# Altium schematic sheet PAGE2 - PWR, MODULE.SchDoc
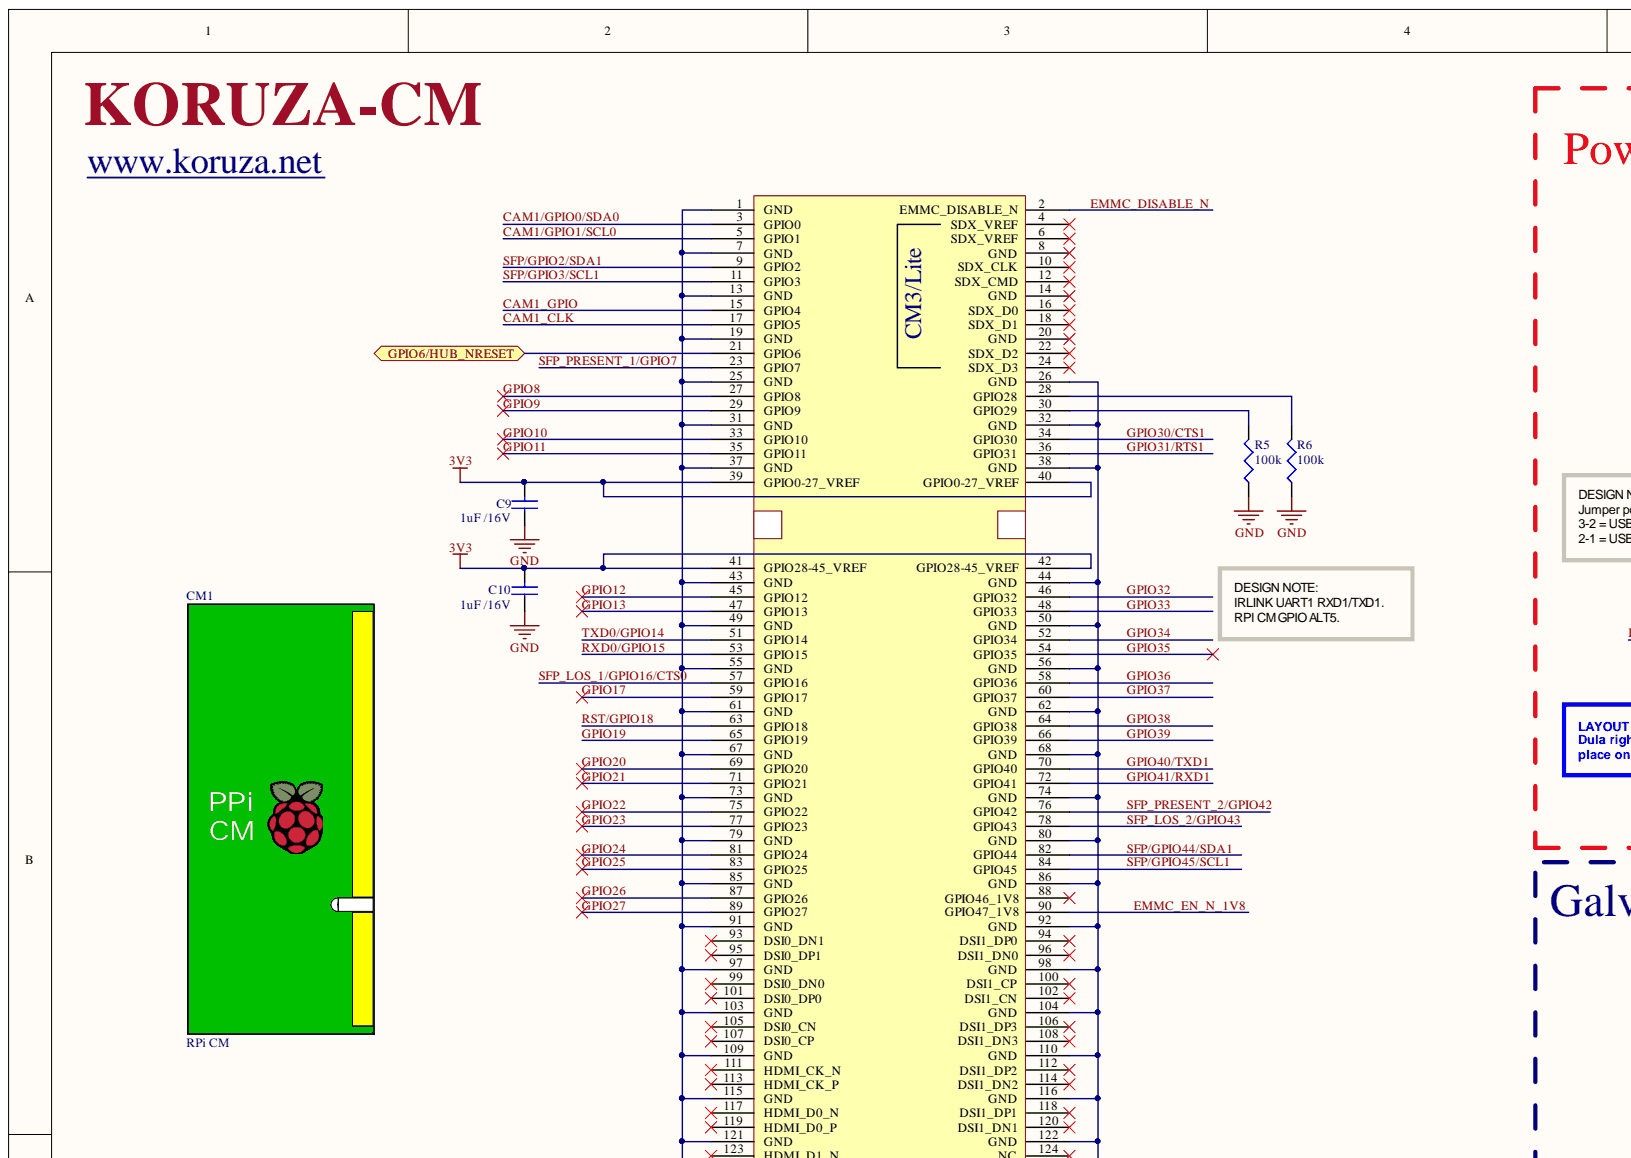
[diagram p0: PAGE2 - PWR, MODULE.SchDoc, top left]
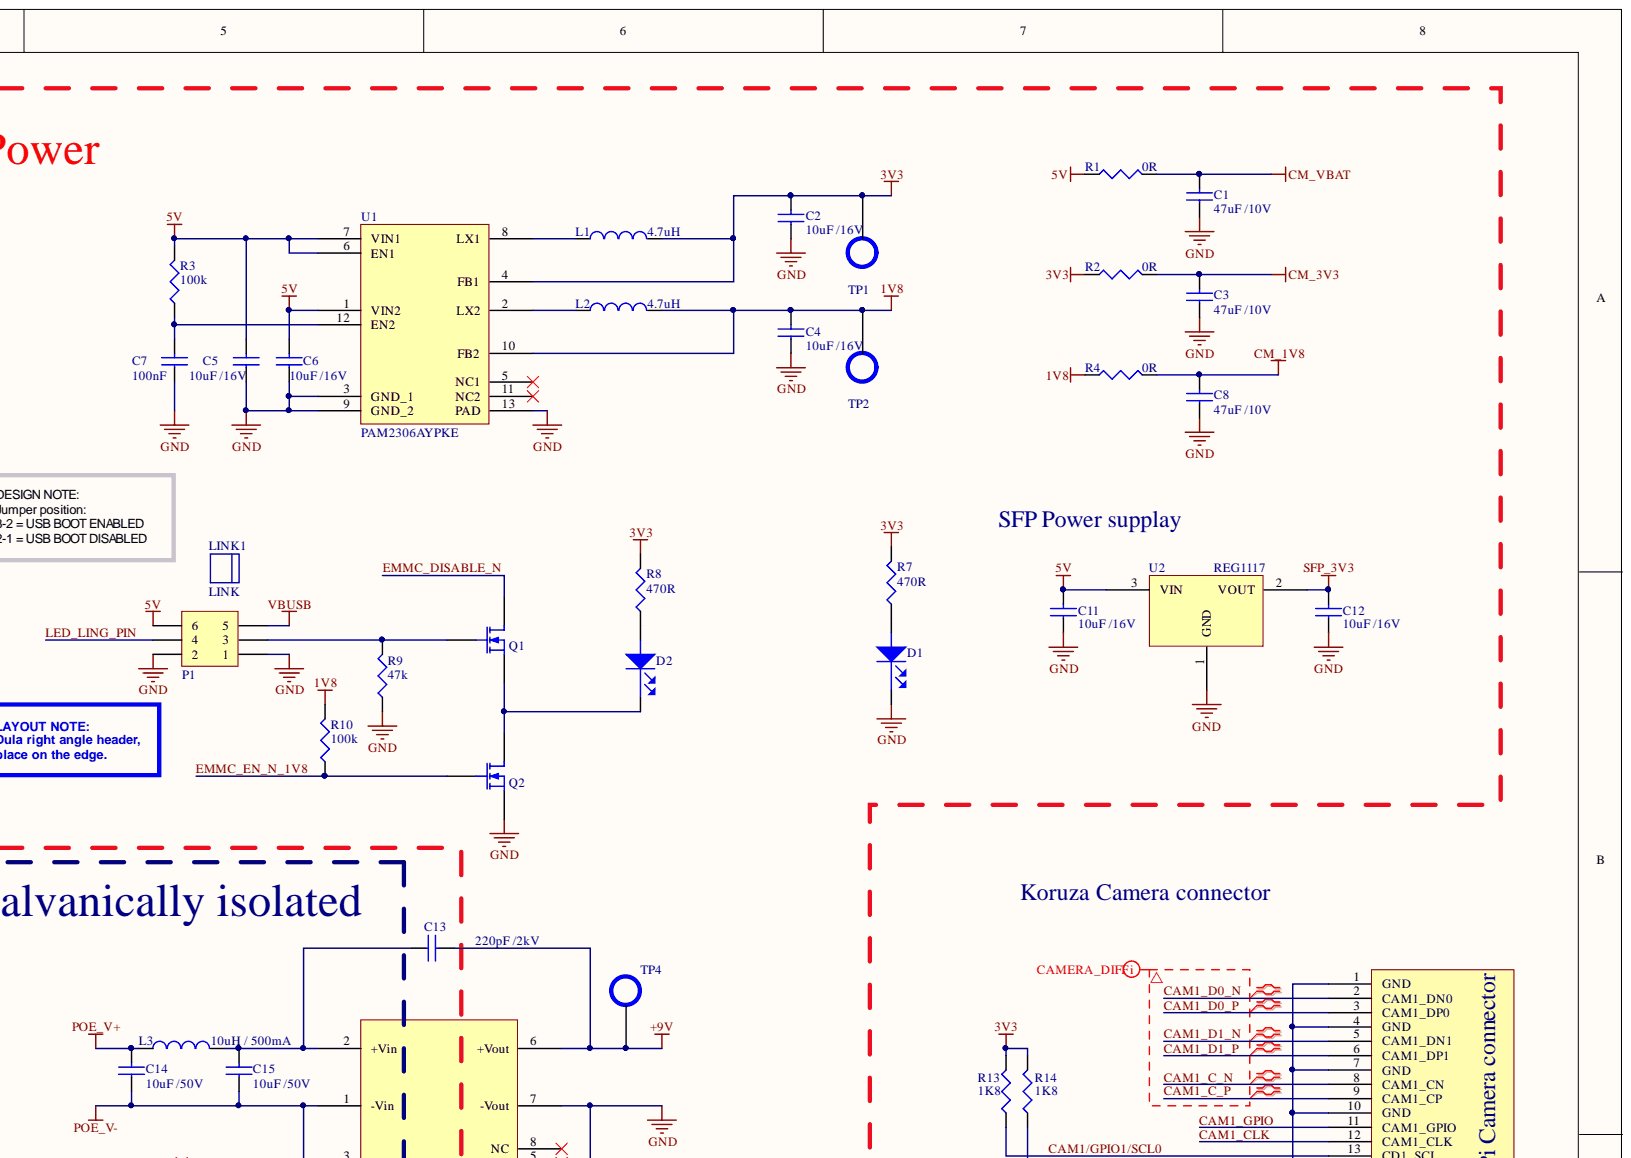
[diagram p1: PAGE2 - PWR, MODULE.SchDoc, top right]
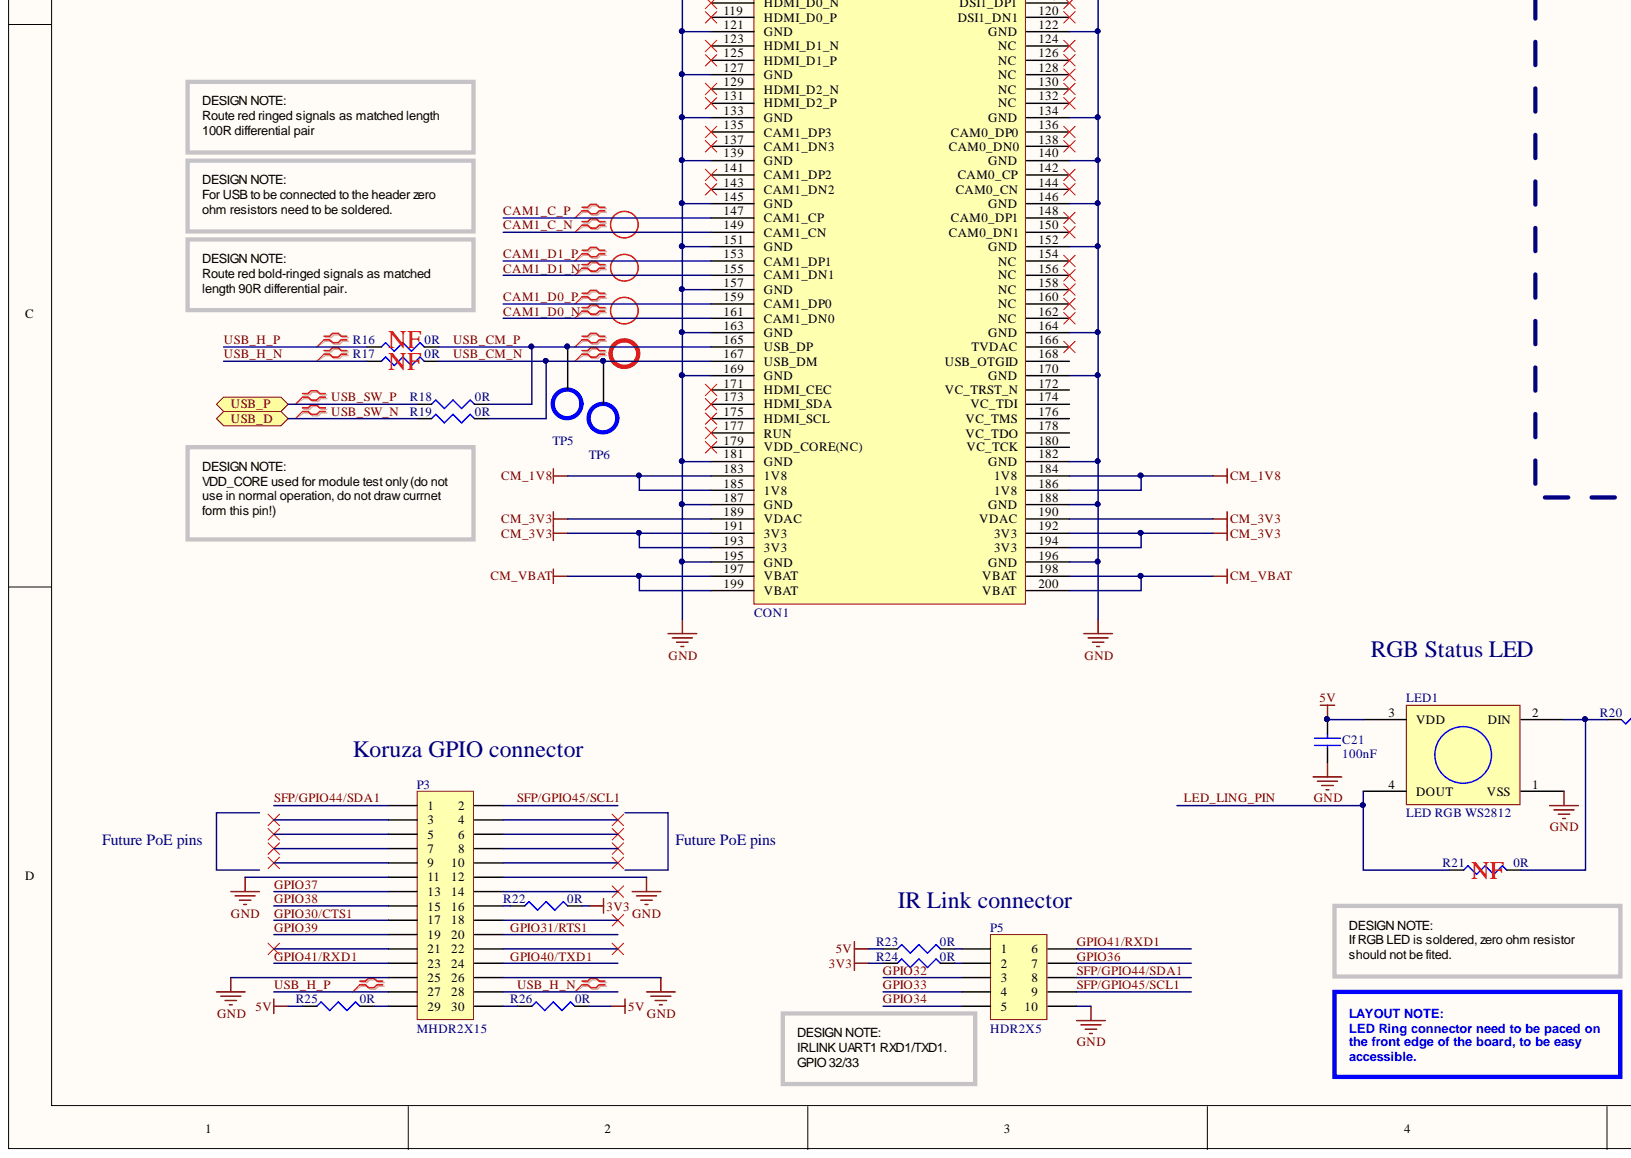
[diagram p2: PAGE2 - PWR, MODULE.SchDoc, bottom left]
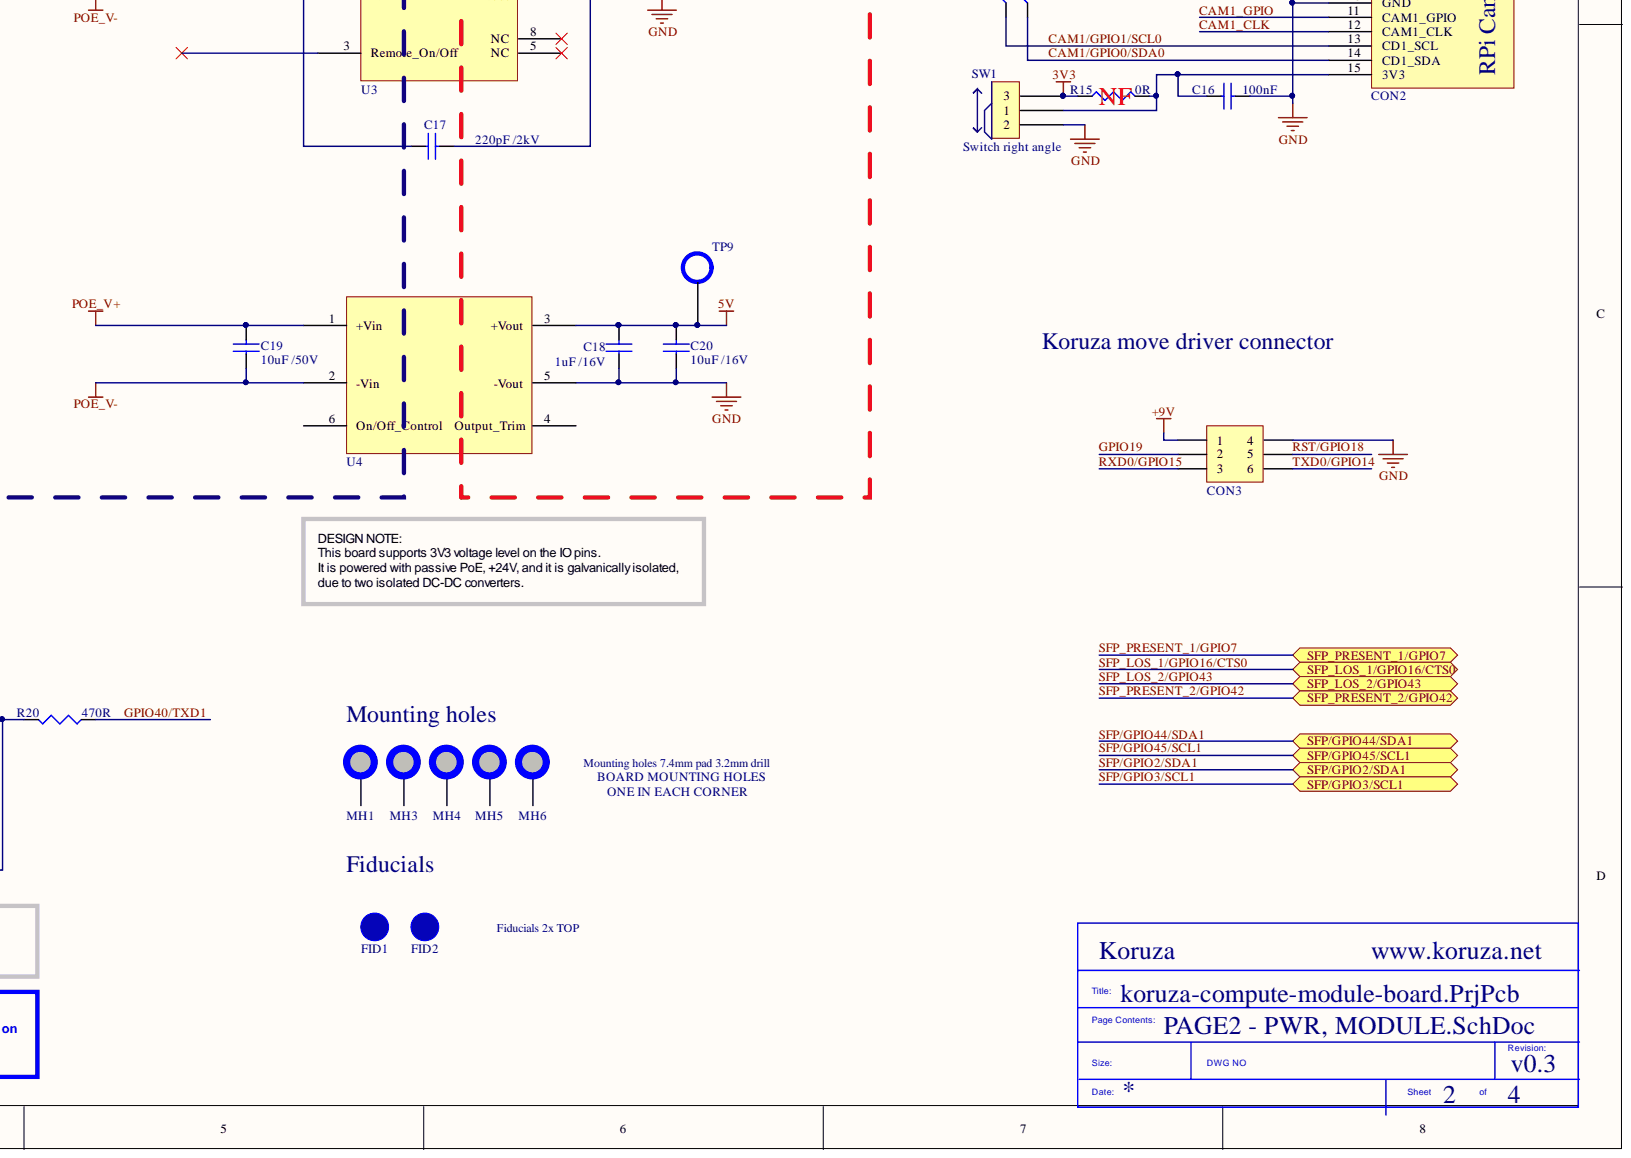
[diagram p3: PAGE2 - PWR, MODULE.SchDoc, bottom right]

## Parts
C1  47uF /10V  [CAP0805]
C2  10uF /16V  [CAP0805]
C3  47uF /10V  [CAP0805]
C4  10uF /16V  [CAP0805]
C5  10uF /16V  [CAP0805]
C6  10uF /16V  [CAP0805]
C7  100nF  [CAP0603]
C8  47uF /10V  [CAP0805]
C9  1uF /16V  [CAP0603]
C10  1uF /16V  [CAP0603]
C11  10uF /16V  [CAP0603]
C12  10uF /16V  [CAP0603]
C13  220pF /2kV  [CAP1206]
C14  10uF /50V  [CAP1206]
C15  10uF /50V  [CAP1206]
C16  100nF  [CAP0603]
C17  220pF /2kV  [CAP1206]
C18  1uF /16V  [CAP0805]
C19  10uF /50V  [CAP1206]
C20  10uF /16V  [CAP0805]
C21  100nF  [CAP0603]
CM1  RPi CM  (mechanical)
CON1  1473005-4  [BTB-SM0-6-2V200]  (value not drawn)
CON2  value Camera  [1-1734248-5]  (hidden comment: 1-1734248-5)
CON3  MICROMATCH 6 SMD  [MICROMATCH2X3]  (value not drawn)
D1  LED RED  [LED0603]  (value not drawn)
D2  LED RED  [LED0603]  (value not drawn)
FID1  FID_1MMC  [FID_40X120D]  (value not drawn)  (no BOM)
FID2  FID_1MMC  [FID_40X120D]  (value not drawn)  (no BOM)
L1  value 4.7uH  [INDP4040X18N]  (hidden comment: SRN4018-4R7M)
L2  value 4.7uH  [INDP4040X18N]  (hidden comment: SRN4018-4R7M)
L3  10uH / 500mA  [IND1212]
LED1  LED RGB WS2812  [LED_SMD5050]
LINK1  LINK  [JUMPER]  (mechanical)
MH1  MH_7.4MMNC_3.2MMD  [MH_7.4MMD_3.2MMD_NON_COPPER_NPTH]  (value not drawn)  (no BOM)
MH3  MH_7.4MMNC_3.2MMD  [MH_7.4MMD_3.2MMD_NON_COPPER_NPTH]  (value not drawn)  (no BOM)
MH4  MH_7.4MMNC_3.2MMD  [MH_7.4MMD_3.2MMD_NON_COPPER_NPTH]  (value not drawn)  (no BOM)
MH5  MH_7.4MMNC_3.2MMD  [MH_7.4MMD_3.2MMD_NON_COPPER_NPTH]  (value not drawn)  (no BOM)
MH6  MH_7.4MMNC_3.2MMD  [MH_7.4MMD_3.2MMD_NON_COPPER_NPTH]  (value not drawn)  (no BOM)
P1  MHDR2X3H  [HDR2X3_2.54MM_ANGLE]  (value not drawn)
P3  MHDR2X15  [HDR2X15]
P5  HDR2X5  [HDR2X5_CEN]
Q1  DMG1012T-7  [DMG1012T-7]  (value not drawn)
Q2  DMG1012T-7  [DMG1012T-7]  (value not drawn)
R1  0R  [RES1206]
R2  0R  [RES1206]
R3  100k  [RES0603]
R4  0R  [RES1206]
R5  100k  [RES0603]
R6  100k  [RES0603]
R7  470R  [RES0603]
R8  470R  [RES0603]
R9  47k  [RES0603]
R10  100k  [RES0603]
R13  1K8  [RES0603]
R14  1K8  [RES0603]
R15  0R  [RES0603]
R16  0R  [RES0603]
R17  0R  [RES0603]
R18  0R  [RES0603]
R19  0R  [RES0603]
R20  470R  [RES0603]
R21  0R  [RES0603]
R22  0R  [RES0603]
R23  0R  [RES0603]
R24  0R  [RES0603]
R25  0R  [RES0603]
R26  0R  [RES0603]
SW1  Switch right angle  [SW ANGLE 3PIN]
TP1  TEST_POINT  [TEST_POINT]  (value not drawn)  (no BOM)
TP2  TEST_POINT  [TEST_POINT]  (value not drawn)  (no BOM)
TP4  TEST_POINT  [TEST_POINT]  (value not drawn)  (no BOM)
TP5  TEST_POINT  [TEST_POINT]  (value not drawn)  (no BOM)
TP6  TEST_POINT  [TEST_POINT]  (value not drawn)  (no BOM)
TP9  TEST_POINT  [TEST_POINT]  (value not drawn)  (no BOM)
U1  PAM2306AYPKE  [WDFN12L]
U2  REG1117  [TI-DCY4_N]
U3  ITX2409SA  [DCDC_M1]  (value not drawn)
U4  SPM15-050-Q12P-C  [DCDC_M2]  (value not drawn)

## Ports
GPIO6/HUB_NRESET, SFP/GPIO2/SDA1, SFP/GPIO3/SCL1, SFP/GPIO44/SDA1, SFP/GPIO45/SCL1, SFP_LOS_1/GPIO16/CTS0, SFP_LOS_2/GPIO43, SFP_PRESENT_1/GPIO7, SFP_PRESENT_2/GPIO42, USB_D, USB_P

## Nets
1V8: C4.2 L2.2 R4.1 R10.2 TP2.1 U1.10(FB2)
3V3: C2.2 C9.2 C10.2 CON1.39(GPIO0-27_VREF) CON1.40(GPIO0-27_VREF) CON1.41(GPIO28-45_VREF) CON1.42(GPIO28-45_VREF) L1.2 R2.1 R7.2 R8.2 R13.2 R14.2 R15.1 R22.2 R24.1 SW1.3 TP1.1 U1.4(FB1)
5V: C5.2 C6.2 C11.2 C18.2 C20.2 C21.2 LED1.3(VDD) P1.6 R1.1 R3.2 R23.1 R25.1 R26.2 TP9.1 U1.1(VIN2) U1.6(EN1) U1.7(VIN1) U2.3 U4.3(+Vout)
+9V: C13.2 CON3.1 TP4.1 U3.6(+Vout)
CM_1V8: C8.2 CON1.183(1V8) CON1.184(1V8) CON1.185(1V8) CON1.186(1V8) R4.2
CM_3V3: C3.2 CON1.189(VDAC) CON1.190(VDAC) CON1.191(3V3) CON1.192(3V3) CON1.193(3V3) CON1.194(3V3) R2.2
CM_VBAT: C1.2 CON1.197(VBAT) CON1.198(VBAT) CON1.199(VBAT) CON1.200(VBAT) R1.2
GND: C1.1 C2.1 C3.1 C4.1 C5.1 C6.1 C7.1 C8.1 C9.1 C10.1 C11.1 C12.1 C16.2 C17.2 C18.1 C20.1 C21.1 CON1.1(GND) CON1.7(GND) CON1.13(GND) CON1.19(GND) CON1.25(GND) CON1.26(GND) CON1.31(GND) CON1.32(GND) CON1.37(GND) CON1.38(GND) CON1.43(GND) CON1.44(GND) CON1.49(GND) CON1.50(GND) CON1.55(GND) CON1.56(GND) CON1.61(GND) CON1.62(GND) CON1.67(GND) CON1.68(GND) CON1.73(GND) CON1.74(GND) CON1.79(GND) CON1.80(GND) CON1.85(GND) CON1.86(GND) CON1.91(GND) CON1.92(GND) CON1.97(GND) CON1.98(GND) CON1.103(GND) CON1.104(GND) CON1.109(GND) CON1.110(GND) CON1.115(GND) CON1.116(GND) CON1.121(GND) CON1.122(GND) CON1.127(GND) CON1.133(GND) CON1.134(GND) CON1.139(GND) CON1.140(GND) CON1.145(GND) CON1.146(GND) CON1.151(GND) CON1.152(GND) CON1.157(GND) CON1.163(GND) CON1.164(GND) CON1.169(GND) CON1.170(GND) CON1.181(GND) CON1.182(GND) CON1.187(GND) CON1.188(GND) CON1.195(GND) CON1.196(GND) CON2.1(GND) CON2.4(GND) CON2.7(GND) CON2.10(GND) CON3.4 D1.1 LED1.1(VSS) P1.1 P1.2 P3.11 P3.12 P3.25 P3.26 P5.10 Q2.3 R5.1 R6.1 R9.1 SW1.2 U1.3(GND_1) U1.9(GND_2) U1.13(PAD) U2.1 U3.7(-Vout) U4.5(-Vout)
POE_V+: C14.2 C19.2 L3.1 U4.1(+Vin)
POE_V-: C14.1 C15.1 C17.1 C19.1 U3.1(-Vin) U4.2(-Vin)
SFP_3V3: C12.2 U2.2
VBUSB: P1.5
CAM1/GPIO0/SDA0: CON1.3(GPIO0) CON2.14(CD1_SDA) R14.1
CAM1/GPIO1/SCL0: CON1.5(GPIO1) CON2.13(CD1_SCL) R13.1
CAM1_C_N: CON1.149(CAM1_CN) CON2.8(CAM1_CN)
CAM1_C_P: CON1.147(CAM1_CP) CON2.9(CAM1_CP)
CAM1_CLK: CON1.17(GPIO5) CON2.12(CAM1_CLK)
CAM1_D0_N: CON1.161(CAM1_DN0) CON2.2(CAM1_DN0)
CAM1_D0_P: CON1.159(CAM1_DP0) CON2.3(CAM1_DP0)
CAM1_D1_N: CON1.155(CAM1_DN1) CON2.5(CAM1_DN1)
CAM1_D1_P: CON1.153(CAM1_DP1) CON2.6(CAM1_DP1)
CAM1_GPIO: CON1.15(GPIO4) CON2.11(CAM1_GPIO)
EMMC_DISABLE_N: CON1.2(EMMC_DISABLE_N) Q1.1
EMMC_EN_N_1V8: CON1.90(GPIO47_1V8) Q2.2 R10.1
GPIO6/HUB_NRESET: CON1.21(GPIO6) ; port GPIO6/HUB_NRESET
GPIO8: CON1.27(GPIO8)
GPIO9: CON1.29(GPIO9)
GPIO10: CON1.33(GPIO10)
GPIO11: CON1.35(GPIO11)
GPIO12: CON1.45(GPIO12)
GPIO13: CON1.47(GPIO13)
GPIO17: CON1.59(GPIO17)
GPIO19: CON1.65(GPIO19) CON3.2
GPIO20: CON1.69(GPIO20)
GPIO21: CON1.71(GPIO21)
GPIO22: CON1.75(GPIO22)
GPIO23: CON1.77(GPIO23)
GPIO24: CON1.81(GPIO24)
GPIO25: CON1.83(GPIO25)
GPIO26: CON1.87(GPIO26)
GPIO27: CON1.89(GPIO27)
GPIO30/CTS1: CON1.34(GPIO30) P3.17
GPIO31/RTS1: CON1.36(GPIO31) P3.20
GPIO32: CON1.46(GPIO32) P5.3
GPIO33: CON1.48(GPIO33) P5.4
GPIO34: CON1.52(GPIO34) P5.5
GPIO35: CON1.54(GPIO35)
GPIO36: CON1.58(GPIO36) P5.7
GPIO37: CON1.60(GPIO37) P3.13
GPIO38: CON1.64(GPIO38) P3.15
GPIO39: CON1.66(GPIO39) P3.19
GPIO40/TXD1: CON1.70(GPIO40) P3.24 R20.1
GPIO41/RXD1: CON1.72(GPIO41) P3.23 P5.6
LED_LING_PIN: LED1.4(DOUT) P1.4 R21.1
RST/GPIO18: CON1.63(GPIO18) CON3.5
RXD0/GPIO15: CON1.53(GPIO15) CON3.3
SFP/GPIO2/SDA1: CON1.9(GPIO2) ; port SFP/GPIO2/SDA1
SFP/GPIO3/SCL1: CON1.11(GPIO3) ; port SFP/GPIO3/SCL1
SFP/GPIO44/SDA1: CON1.82(GPIO44) P3.1 P5.8 ; port SFP/GPIO44/SDA1
SFP/GPIO45/SCL1: CON1.84(GPIO45) P3.2 P5.9 ; port SFP/GPIO45/SCL1
SFP_LOS_1/GPIO16/CTS0: CON1.57(GPIO16) ; port SFP_LOS_1/GPIO16/CTS0
SFP_LOS_2/GPIO43: CON1.78(GPIO43) ; port SFP_LOS_2/GPIO43
SFP_PRESENT_1/GPIO7: CON1.23(GPIO7) ; port SFP_PRESENT_1/GPIO7
SFP_PRESENT_2/GPIO42: CON1.76(GPIO42) ; port SFP_PRESENT_2/GPIO42
TXD0/GPIO14: CON1.51(GPIO14) CON3.6
USB_CM_N: CON1.167(USB_DM) R17.2 R19.2 TP6.1
USB_CM_P: CON1.165(USB_DP) R16.2 R18.2 TP5.1
USB_H_N: P3.28 R17.1
USB_H_P: P3.27 R16.1
USB_SW_N: R19.1 ; port USB_D
USB_SW_P: R18.1 ; port USB_P
NetC7_2: C7.2 R3.1 U1.12(EN2)
NetC13_1: C13.1 C15.2 L3.2 U3.2(+Vin)
NetC16_1: C16.1 CON2.15(3V3) R15.2 SW1.1
NetCON1_28: CON1.28(GPIO28) R6.2
NetCON1_30: CON1.30(GPIO29) R5.2
NetD1_2: D1.2 R7.1
NetD2_1: D2.1 Q1.3 Q2.1
NetD2_2: D2.2 R8.1
NetL1_1: L1.1 U1.8(LX1)
NetL2_1: L2.1 U1.2(LX2)
NetLED1_2: LED1.2(DIN) R20.2 R21.2
NetP1_3: P1.3 Q1.2 R9.2
NetP3_16: P3.16 R22.1
NetP3_29: P3.29 R25.2
NetP3_30: P3.30 R26.1
NetP5_1: P5.1 R23.2
NetP5_2: P5.2 R24.2
N00050:

Not connected: CON1 4(SDX_VREF) 6(SDX_VREF) 8(GND) 10(SDX_CLK) 12(SDX_CMD) 14(GND) 16(SDX_D0) 18(SDX_D1) 20(GND) 22(SDX_D2) 24(SDX_D3) 88(GPIO46_1V8) 93(DSI0_DN1) 94(DSI1_DP0) 95(DSI0_DP1) 96(DSI1_DN0) 99(DSI0_DN0) 100(DSI1_CP) 101(DSI0_DP0) 102(DSI1_CN) 105(DSI0_CN) 106(DSI1_DP3) 107(DSI0_CP) 108(DSI1_DN3) 111(HDMI_CK_N) 112(DSI1_DP2) 113(HDMI_CK_P) 114(DSI1_DN2) 117(HDMI_D0_N) 118(DSI1_DP1) 119(HDMI_D0_P) 120(DSI1_DN1) 123(HDMI_D1_N) 124(NC) 125(HDMI_D1_P) 126(NC) 128(NC) 129(HDMI_D2_N) 130(NC) 131(HDMI_D2_P) 132(NC) 135(CAM1_DP3) 136(CAM0_DP0) 137(CAM1_DN3) 138(CAM0_DN0) 141(CAM1_DP2) 142(CAM0_CP) 143(CAM1_DN2) 144(CAM0_CN) 148(CAM0_DP1) 150(CAM0_DN1) 154(NC) 156(NC) 158(NC) 160(NC) 162(NC) 166(TVDAC) 168(USB_OTGID) 171(HDMI_CEC) 172(VC_TRST_N) 173(HDMI_SDA) 174(VC_TDI) 175(HDMI_SCL) 176(VC_TMS) 177(RUN) 178(VC_TDO) 179(VDD_CORE(NC)) 180(VC_TCK); MH1 1; MH3 1; MH4 1; MH5 1; MH6 1; P3 3 4 5 6 7 8 9 10 14 18 21 22; U1 5(NC1) 11(NC2); U3 3(Remote_On/Off) 5(NC) 8(NC); U4 4(Output_Trim) 6(On/Off_Control)
